# Altium schematic sheet USB & Battery Charging.SchDoc
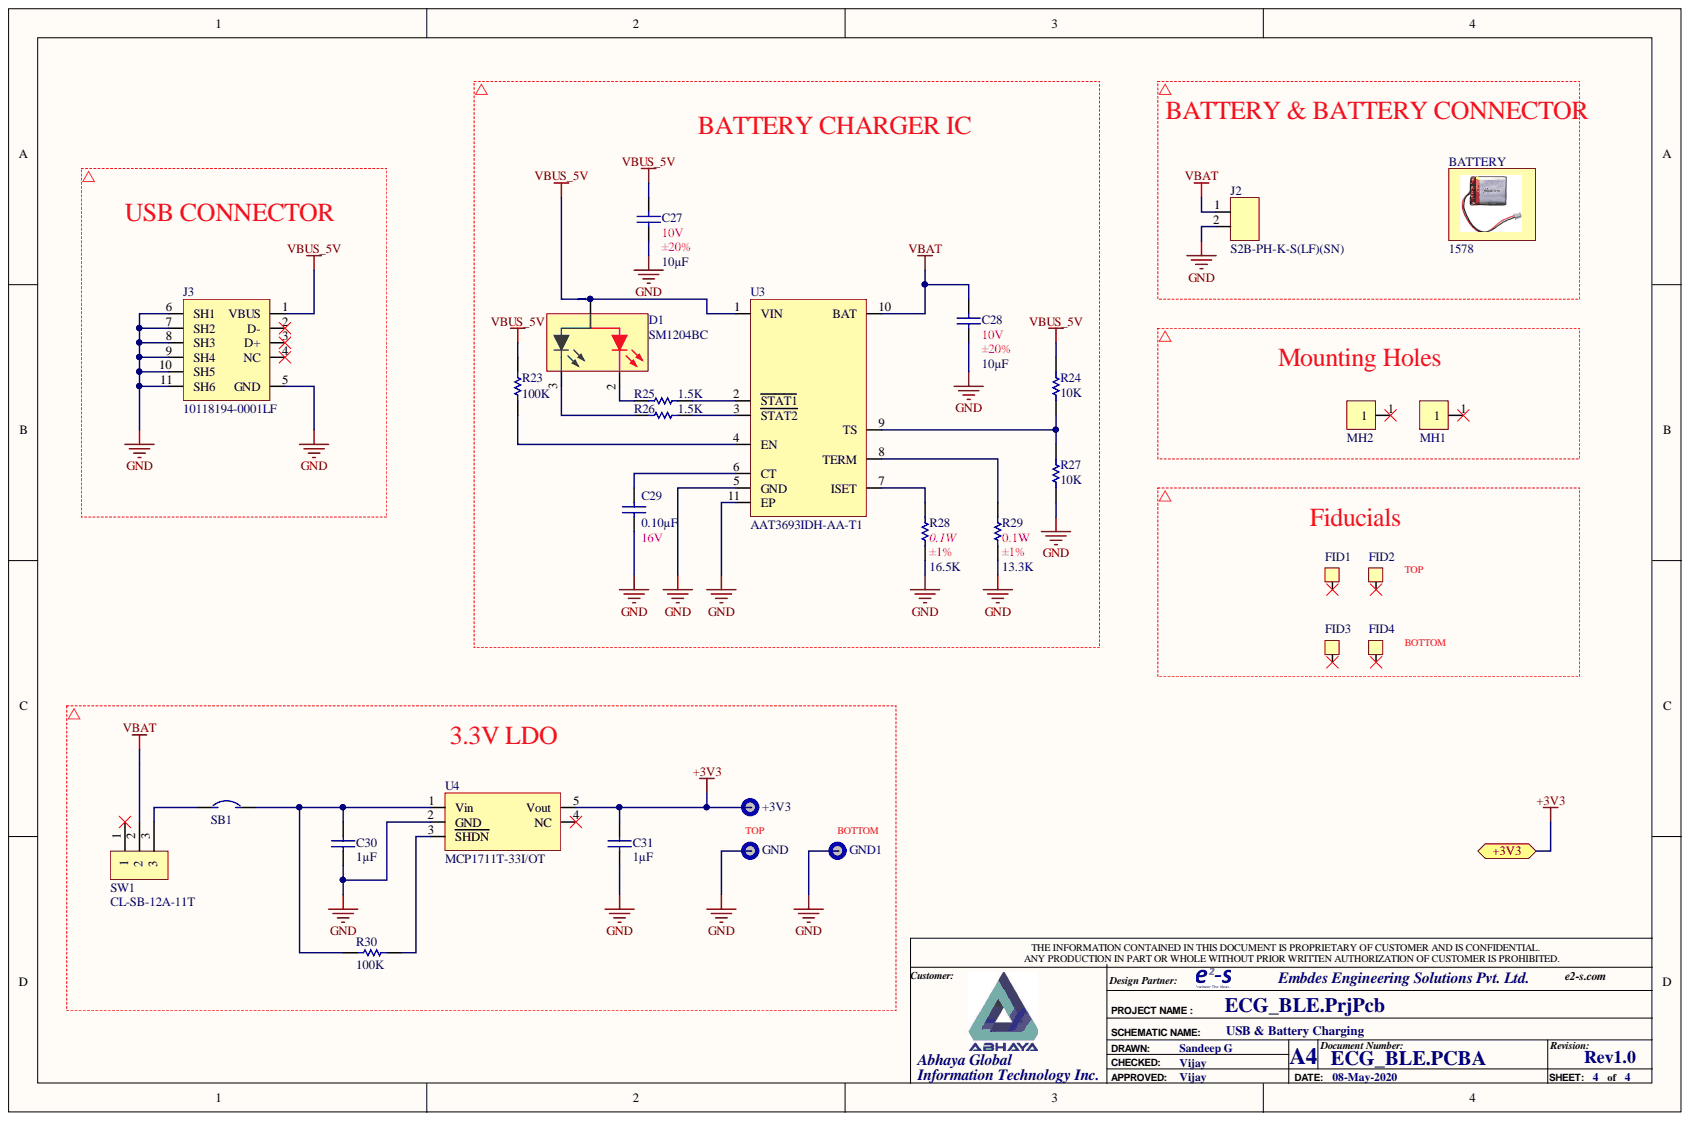
[diagram p0: USB & Battery Charging.SchDoc]

## Parts
+3V3  TEST POINT  [TP_40X40]  (value not drawn)
C27  value 10µF  1st Auxiliary Field: 10V  2nd Auxiliary Field: ±20%  [SMDC0402]  (hidden comment: CAP CER 10UF 10V X5R 0402)
C28  value 10µF  1st Auxiliary Field: 10V  2nd Auxiliary Field: ±20%  [SMDC0402]  (hidden comment: CAP CER 10UF 10V X5R 0402)
C29  value 0.10µF  1st Auxiliary Field: 16V  [SMDC0402]  (hidden comment: CAP CER 0.1UF 16V X7R 0402)
C30  value 1µF  [SMDC0402]  (hidden comment: CAP CER 1UF 16V X5R 0402)
C31  value 1µF  [SMDC0402]  (hidden comment: CAP CER 1UF 16V X5R 0402)
D1  value SM1204BC  Manufacturer Part Number: SM1204BC  [LED-SM1204BC]  (hidden comment: LED GREEN/RED CLEAR 1204 SMD R/A)
FID1  FID40X40  [FID40X40]  (value not drawn)
FID2  FID40X40  [FID40X40]  (value not drawn)
FID3  FID40X40  [FID40X40]  (value not drawn)
FID4  FID40X40  [FID40X40]  (value not drawn)
GND  TEST POINT  [TP_40X40]  (value not drawn)
GND1  TEST POINT  [TP_40X40]  (value not drawn)
J2  value S2B-PH-K-S(LF)(SN)  Manufacturer Part Number: S2B-PH-K-S(LF)(SN)  [SHDRRA2W70P0_200_1X2_590X790X550P]  (hidden comment: CONN HOUSING PH 2POS 2MM WHITE)
J3  value 10118194-0001LF  Manufacturer Part Number: 10118194-0001LF  [USB_MICRO_B_10118194-0001LF]  (hidden comment: CONN USB MICRO B RECPT SMT R/A)
MH1  Mounting hole  [MH_2P2MM_3P6KP]  (value not drawn)
MH2  Mounting hole  [MH_2P2MM_3P6KP]  (value not drawn)
R23  value 100K  [SMDRC0402]  (hidden comment: RES SMD 100K OHM 1% 1/10W 0402)
R24  value 10K  [SMDR0402]  (hidden comment: RES SMD 10K OHM 1% 1/10W 0402)
R25  value 1.5K  [SMDR0402]  (hidden comment: RES SMD 1.5K OHM 1% 1/10W 0402)
R26  value 1.5K  [SMDR0402]  (hidden comment: RES SMD 1.5K OHM 1% 1/10W 0402)
R27  value 10K  [SMDR0402]  (hidden comment: RES SMD 10K OHM 1% 1/10W 0402)
R28  value 16.5K  1st Auxiliary Field: 0.1W  2nd Auxiliary Field: ±1%  [SMDR0402]  (hidden comment: RES SMD 16.5K OHM 1% 1/10W 0402)
R29  value 13.3K  1st Auxiliary Field: 0.1W  2nd Auxiliary Field: ±1%  [SMDR0402]  (hidden comment: RES SMD 13.3K OHM 1% 1/10W 0402)
R30  value 100K  [SMDRC0402]  (hidden comment: RES SMD 100K OHM 1% 1/10W 0402)
SB1  EMS_SB0805  [SB_0805]  (value not drawn)
SW1  value CL-SB-12A-11T  Manufacturer Part Number: CL-SB-12A-11T  [SWITCH_CLSB12A11T]  (hidden comment: SWITCH SLIDE SPDT 200MA 12V)
U3  value AAT3693IDH-AA-T1  Manufacturer Part Number: AAT3693IDH-AA-T1  [SON40P220X220x80_HS-11N]  (hidden comment: 1.6A LINEAR LI-ION CHGR 10TDFN)
U4  value MCP1711T-33I/OT  Manufacturer Part Number: MCP1711T-33I/OT  [SOT95P350X145-5N]  (hidden comment: IC REG LINEAR 3.3V 150MA SOT23-5)

## Ports
+3V3

## Nets
GND: C27.2 C28.2 C29.1 C30.1 C31.1 GND.1 GND1.1 J2.2 J3.5(GND) J3.6(SH1) J3.7(SH2) J3.8(SH3) J3.9(SH4) J3.10(SH5) J3.11(SH6) R27.2 R28.1 R29.2 U3.5(GND) U3.11(EP) U4.2(GND)
VBAT: C28.1 J2.1 SW1.2 U3.10(BAT)
VBUS_5V: C27.1 D1.1 J3.1(VBUS) R23.1 R24.1 U3.1(VIN)
+3V3: +3V3.1 C31.2 U4.5(Vout) ; port +3V3
NetC29_2: C29.2 U3.6(CT)
NetC30_2: C30.2 R30.2 SB1.2 U4.1(Vin)
NetD1_2: D1.2 R25.2
NetD1_3: D1.3 R26.2
NetR23_2: R23.2 U3.4(EN)
NetR24_2: R24.2 R27.1 U3.9(TS)
NetR25_1: R25.1 U3.2(STAT1)
NetR26_1: R26.1 U3.3(STAT2)
NetR28_2: R28.2 U3.7(ISET)
NetR29_1: R29.1 U3.8(TERM)
NetR30_1: R30.1 U4.3(SHDN)
NetSB1_1: SB1.1 SW1.3

Not connected: FID1 0; FID2 0; FID3 0; FID4 0; J3 2(D-) 3(D+) 4(NC); MH1 1; MH2 1; SW1 1; U4 4(NC)

## Library data of the parts
+3V3 GND GND1 = EMS-TP40X40-S: 1st Auxiliary Field: Black; Component Kind: Standard; Description: TEST POINT; Internal Part Number: EMS-TP40X40-S; Library Name: Integrated_Master_Library.IntLib; Part Type: TEST POINT
C27 C28 = ECA10U0M10V0-SB: Manufacturer: Samsung Electro-Mechanics America, Inc.; Manufacturer Part Number: CL05A106MP5NUNC; Vendor Part Number: 1276-1450-1-ND; Component Height (mm): 0.70mm; Vendor Name: DigiKey; Component Kind: Standard; Description: CAP CER 10UF 10V X5R 0402; Internal Part Number: ECA10U0M10V0-SB; Library Name: Master_ Library.SchLib; Part Type: CAP CER 10UF 10V X5R 0402; Temp Co: X5R
C29 = ECA0U10K16V0-1-SB: Manufacturer: Samsung Electro-Mechanics America, Inc.; Manufacturer Part Number: CL05B104KO5NNNC; Vendor Part Number: 1276-1001-1-ND; 2nd Auxiliary Field: ±10%; Vendor Name: Digi-Key; Component Kind: Standard; Description: CAP CER 0.1UF 16V X7R 0402; Internal Part Number: ECA0U10K16V0-1-SB; Library Name: Master_ Library.SchLib; Part Type: CAP CER 0.1UF 16V X7R 0402; Temp Co: X7R
C30 C31 = ECA1U00L16V0-SB: Manufacturer: Samsung Electro-Mechanics; Manufacturer Part Number: CL05A105KO5NNNC; Vendor Part Number: 1276-1067-1-ND; 2nd Auxiliary Field: ±10%; 3rd Auxiliary Field: RoHS Compliant; Component Height (mm): 0.55MM; Vendor Name: Digi-Key; 1st Auxiliary Field: 16V; Component Kind: Standard; Description: CAP CER 1UF 16V X5R 0402; Internal Part Number: ECA1U00L16V0-SB; Library Name: Integrated_Master_Library.IntLib; Part Type: CAP CER 1UF 16V X5R 0402; Temp Co: X5R
D1 = ELDGR2V0020M0-S: Manufacturer: Bivar Inc.; Vendor Part Number: 492-1228-1-ND; 1st Auxiliary Field: 2V Green, 2V Red; 2nd Auxiliary Field: 20mA Green, 20mA Red; 3rd Auxiliary Field: RoHS Compliant; Component Height (mm): 1.00mm; Vendor Name: Digi-Key; Component Kind: Standard; Description: LED GREEN/RED CLEAR 1204 SMD R/A; Internal Part Number: ELDGR2V0020M0-S; Part Type: LED GREEN/RED CLEAR 1204 SMD R/A; Library Name: ECG_BLE.SchLib
FID1-FID4 = FID_40X40: Component Kind: Standard; Description: FID40X40; Library Name: Integrated_Master_Library.IntLib
J2 = ECNJSTHE02-T: Manufacturer: JST Sales America Inc.; Vendor Part Number: 455-1719-ND; Component Height (mm): 4.8mm; Vendor Name: Digi-Key; 1st Auxiliary Field: 2 Pos; 2nd Auxiliary Field: 2mm; Component Kind: Standard; Description: CONN HOUSING PH 2POS 2MM WHITE; Internal Part Number: ECNJSTHE02-T; Library Name: Master_ Library.SchLib; Part Type: CONN HOUSING PH 2POS 2MM WHITE
J3 = EINUSBBR06-S-1364: Manufacturer: Amphenol FCI; Vendor Part Number: 609-4618-1-ND; 1st Auxiliary Field: USB - micro B; 2nd Auxiliary Field: 5 POS; 3rd Auxiliary Field: RoHS Compliant; Component Height (mm): 3mm; Temp Co: -30°C ~ 80°C; Vendor Name: Digi-Key; Component Kind: Standard; Description: CONN USB MICRO B RECPT SMT R/A; Internal Part Number: EINUSBBR06-S-1364; Library Name: Master_ Library.SchLib; Part Type: CONN USB MICRO B RECPT SMT R/A
MH1 MH2 = EMS-MH2.2MM: Component Kind: Standard; Description: Mounting hole; Internal Part Number: EMS-MH2.2MM; Library Name: ECG_BLE.SchLib
R23 R30 = ERE100KG110-SB: Manufacturer: Panasonic Electronic Components; Manufacturer Part Number: ERJ-2RKF1003X; Vendor Part Number: P100KLCT-ND; 1st Auxiliary Field: 0.1W; Component Height (mm): 0.40mm; Temp Co: ±100ppm/°C; Vendor Name: Digi-Key; 2nd Auxiliary Field: ±1%; Component Kind: Standard; Description: RES SMD 100K OHM 1% 1/10W 0402; Internal Part Number: ERE100KG110-SB; Library Name: Master_ Library.SchLib; Part Type: RES SMD 100K OHM 1% 1/10W 0402
R24 R27 = ERE10K0G110-SB: Manufacturer: Panasonic Electronic Components; Manufacturer Part Number: ERJ-2RKF1002X; Vendor Part Number: P10.0KLCT-ND; 1st Auxiliary Field: 0.1W; Component Height (mm): 0.40mm; Temp Co: ±100ppm/°C; Vendor Name: Digi-Key; 2nd Auxiliary Field: ±1%; Component Kind: Standard; Description: RES SMD 10K OHM 1% 1/10W 0402; Internal Part Number: ERE10K0G110-SB; Library Name: Master_ Library.SchLib; Part Type: RES SMD 10K OHM 1% 1/10W 0402
R25 R26 = ERE1K50G110-SB: Manufacturer: Panasonic Electronic Components; Manufacturer Part Number: ERJ-2RKF1501X; Vendor Part Number: P1.50KLCT-ND; 1st Auxiliary Field: 0.1W; Component Height (mm): 0.40mm; Temp Co: ±100ppm/°C; Vendor Name: Digi-Key; 2nd Auxiliary Field: ±1%; Component Kind: Standard; Description: RES SMD 1.5K OHM 1% 1/10W 0402; Internal Part Number: ERE1K50G110-SB; Library Name: Master_ Library.SchLib; Part Type: RES SMD 1.5K OHM 1% 1/10W 0402
R28 = ERE16K5G110-SB: Manufacturer: Panasonic Electronic Components; Manufacturer Part Number: ERJ-2RKF1652X; Vendor Part Number: P16.5KLCT-ND; Component Height (mm): 0.40mm; Temp Co: ±100ppm/°C; Vendor Name: Digi-Key; Component Kind: Standard; Description: RES SMD 16.5K OHM 1% 1/10W 0402; Internal Part Number: ERE16K5G110-SB; Library Name: Master_ Library.SchLib; Part Type: RES SMD 16.5K OHM 1% 1/10W 0402
R29 = ERE13K3G110-SB: Manufacturer: Panasonic Electronic Components; Manufacturer Part Number: ERJ-2RKF1332X; Vendor Part Number: P13.3KLCT-ND; Component Height (mm): 0.40mm; Temp Co: ±100ppm/°C; Vendor Name: Digi-Key; Component Kind: Standard; Description: RES SMD 13.3K OHM 1% 1/10W 0402; Internal Part Number: ERE13K3G110-SB; Library Name: Master_ Library.SchLib; Part Type: RES SMD 13.3K OHM 1% 1/10W 0402
SW1 = EMS-CL-SB-12A-11T: Manufacturer: Nidec Copal Electronics; Vendor Part Number: 563-1316-1-ND; 1st Auxiliary Field: 200mA (DC); 3rd Auxiliary Field: ROHS3 Compliant; Component Height (mm): 3.5mm; Temp Co: -40°C ~ 85°C; Vendor Name: Digi-Key; 2nd Auxiliary Field: SPDT; Component Kind: Standard; Description: SWITCH SLIDE SPDT 200MA 12V; Internal Part Number: EMS-CL-SB-12A-11T; Part Type: SWITCH SLIDE SPDT 200MA 12V; Library Name: ECG_BLE.SchLib
U3 = EICSSTDFN-10-1864: Manufacturer: Skyworks Solutions Inc.; Vendor Part Number: 863-1512-1-ND; 1st Auxiliary Field: 7.5V; 3rd Auxiliary Field: ROHS3 Compliant; Component Height (mm): 0.8mm; Temp Co: -40°C ~ 85°C (TA); Vendor Name: Digi-Key; 2nd Auxiliary Field: 1.6A; Component Kind: Standard; Description: 1.6A LINEAR LI-ION CHGR 10TDFN; Internal Part Number: EICSSTDFN-10-1864; Library Name: Master_ Library.SchLib; Part Type: 1.6A LINEAR LI-ION CHGR 10TDFN
U4 = EICMTSOT23-5-****: Manufacturer: Microchip Technology; Vendor Part Number: MCP1711T-33I/OTCT-ND; 1st Auxiliary Field: 6V; 3rd Auxiliary Field: RoHS Compliant; Component Height (mm): 1.45mm; Temp Coefficient: -40°C ~ 85°C; Vendor: Digi-Key; 2nd Auxiliary Field: 150mA; 4th Auxiliary Field: 3.3V; Component Kind: Standard; Description: IC REG LINEAR 3.3V 150MA SOT23-5; Internal Part Number: EICMTSOT23-5-****; Part Type: IC REG LINEAR 3.3V 150MA SOT23-5; Library Name: ECG_BLE.SchLib
SB1 = EMS_SB0805: Component Kind: Net Tie; Internal Part Number: EMS_SB0805; Library Name: Masterlib_auto.SchLib
